# Altium schematic sheet VCA.SchDoc
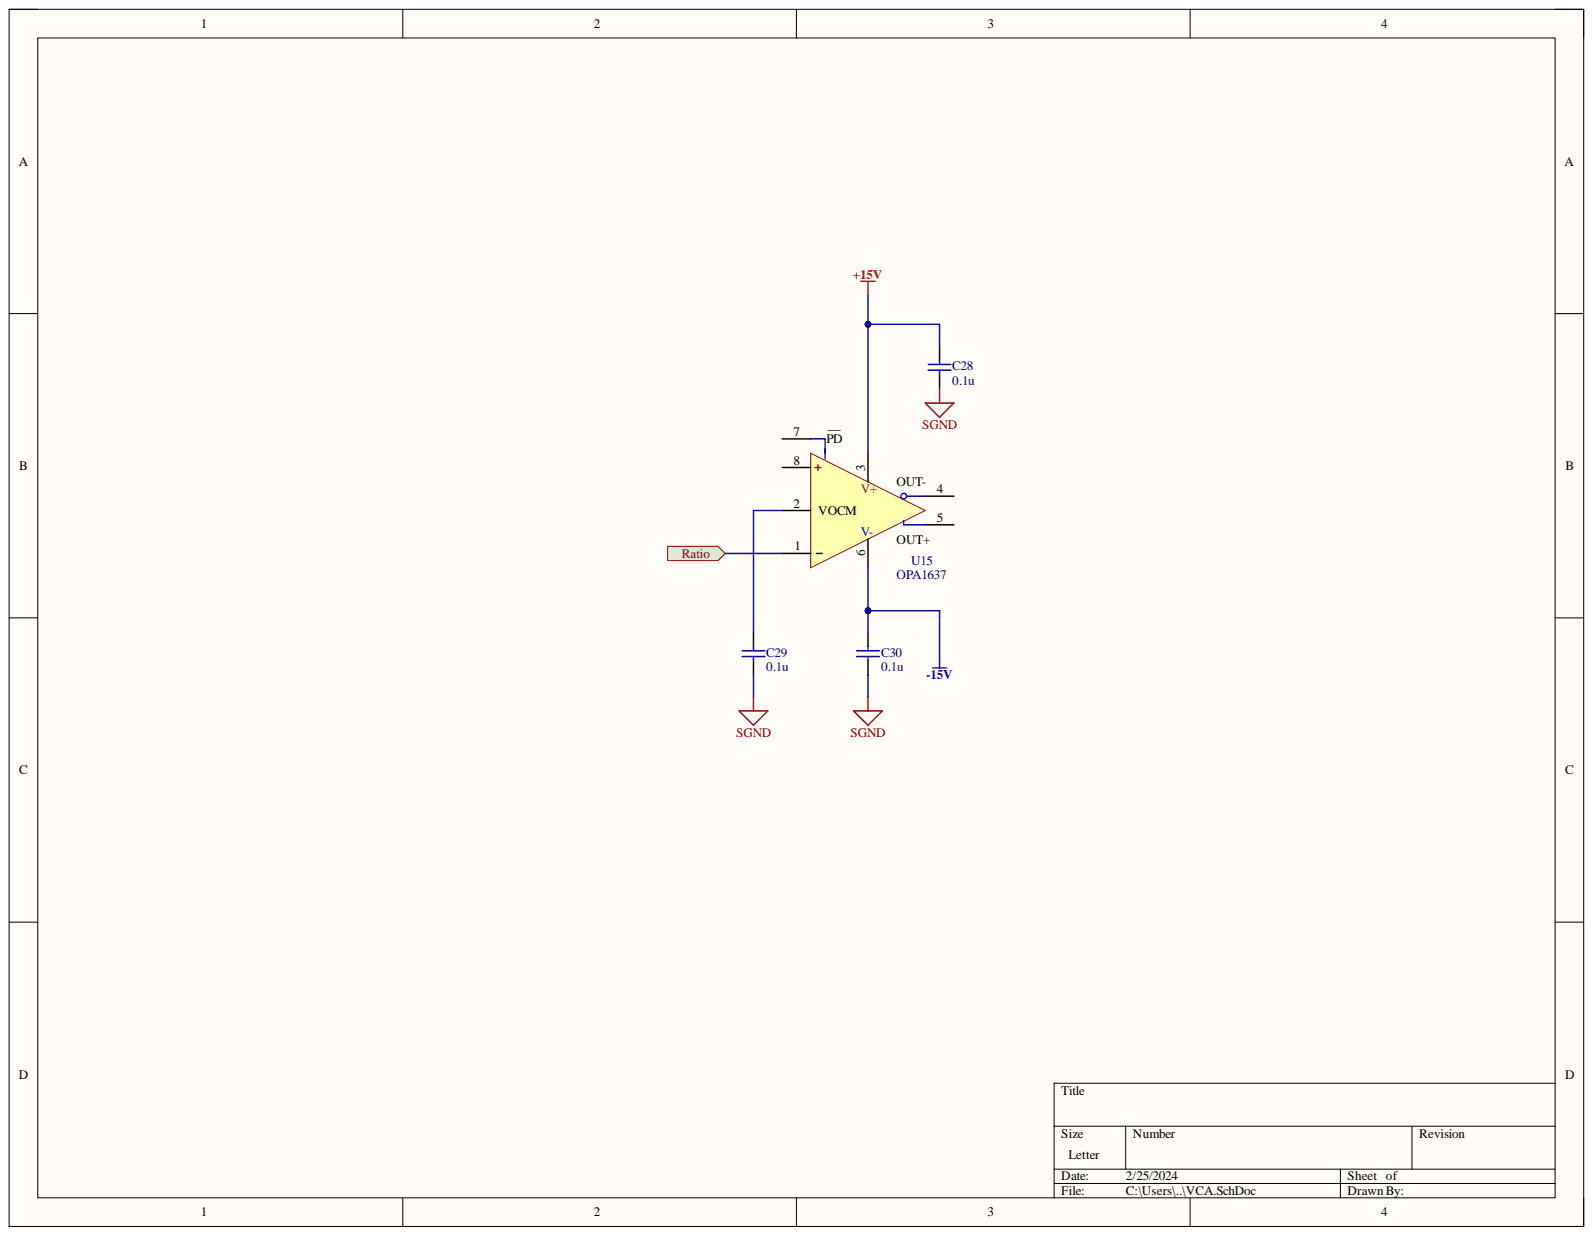
[diagram p0: VCA.SchDoc]

## Parts
C28  value 0.1u  (hidden comment: Capacitor)
C29  value 0.1u  (hidden comment: Capacitor)
C30  value 0.1u  (hidden comment: Capacitor)
U15  OPA1637  [FP-DGK0008A-IPC_A]

## Ports
Ratio

## Nets
+15V: C28.2 U15.3(V+)
-15V: C30.2 U15.6(V-)
SGND: C28.1 C29.1 C30.1
Ratio: U15.1 ; port Ratio
NetC29_2: C29.2 U15.2(VOCM)

Not connected: U15 4 5 7(PD) 8

## Library data of the parts
C28-C30 = Capacitor: SimulationGenericComponent: True; Component Kind: Standard; Description: Capacitor; Library Name: Simulation Generic Components.IntLib; Simulation: C_Capacitor_Default
U15 = OPA1637DGKT: Fully differential amplifier; Component Kind: Standard; Library Name: DRC.SchLib
